# Altium schematic sheet usb_ethernet.SchDoc(usb_ethernet)
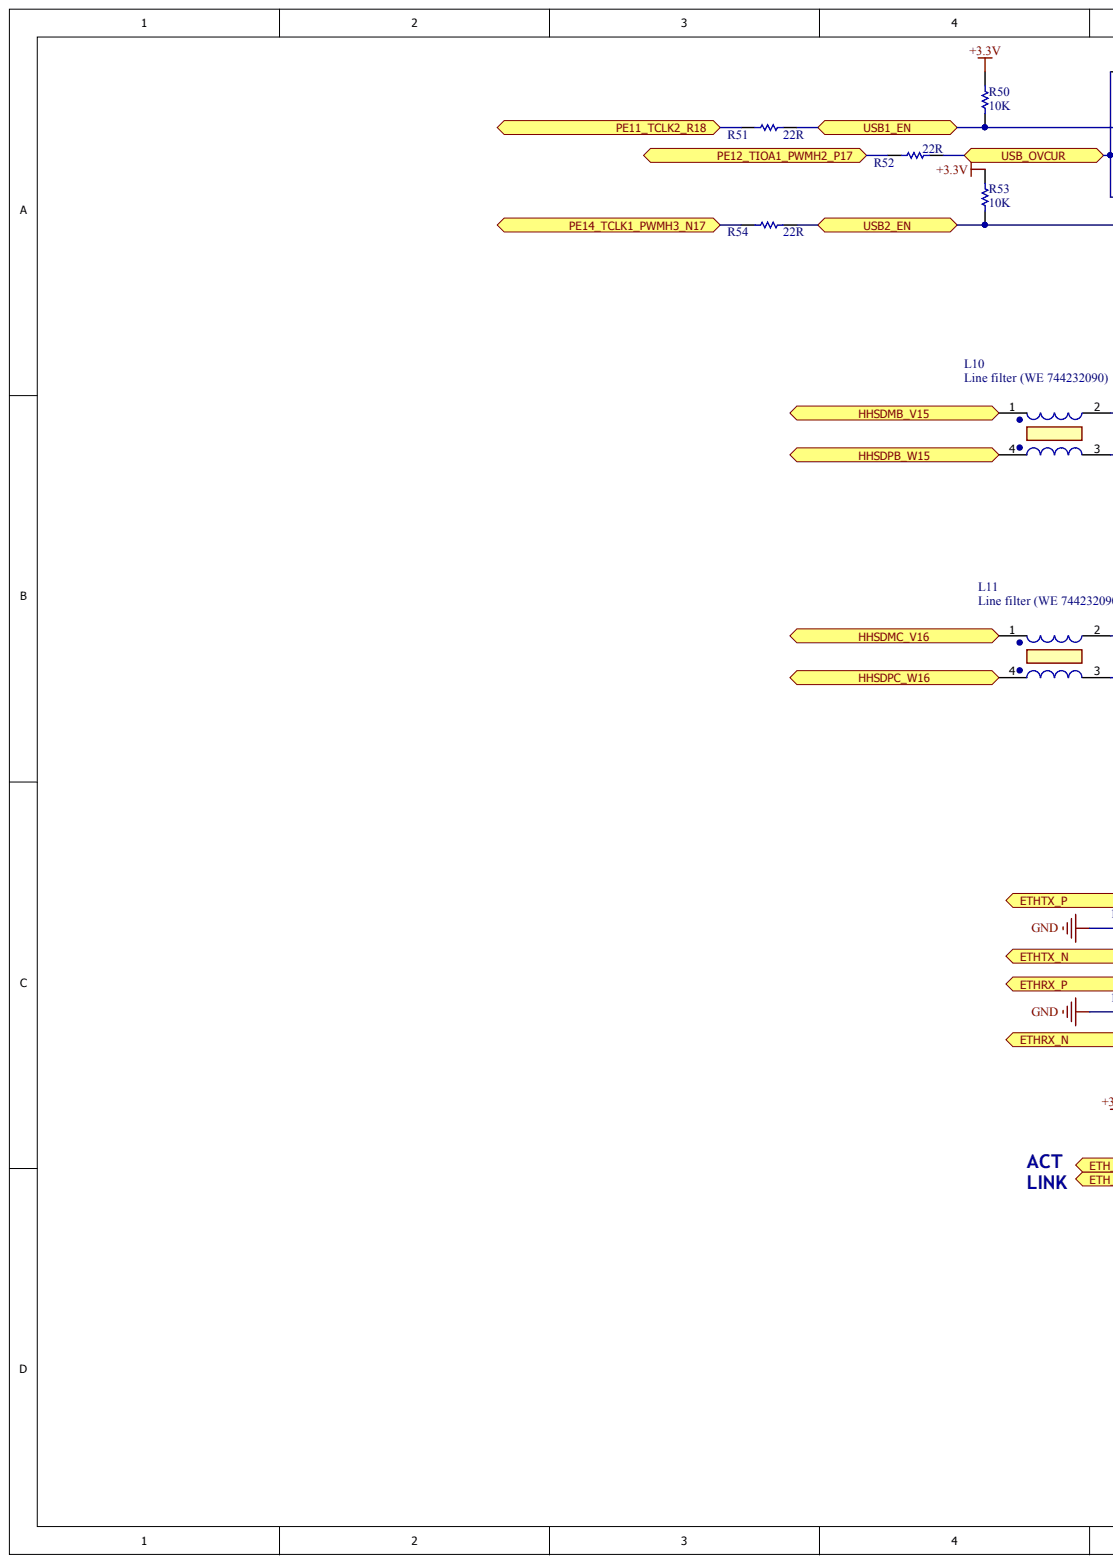
[diagram p0: usb_ethernet.SchDoc, left]
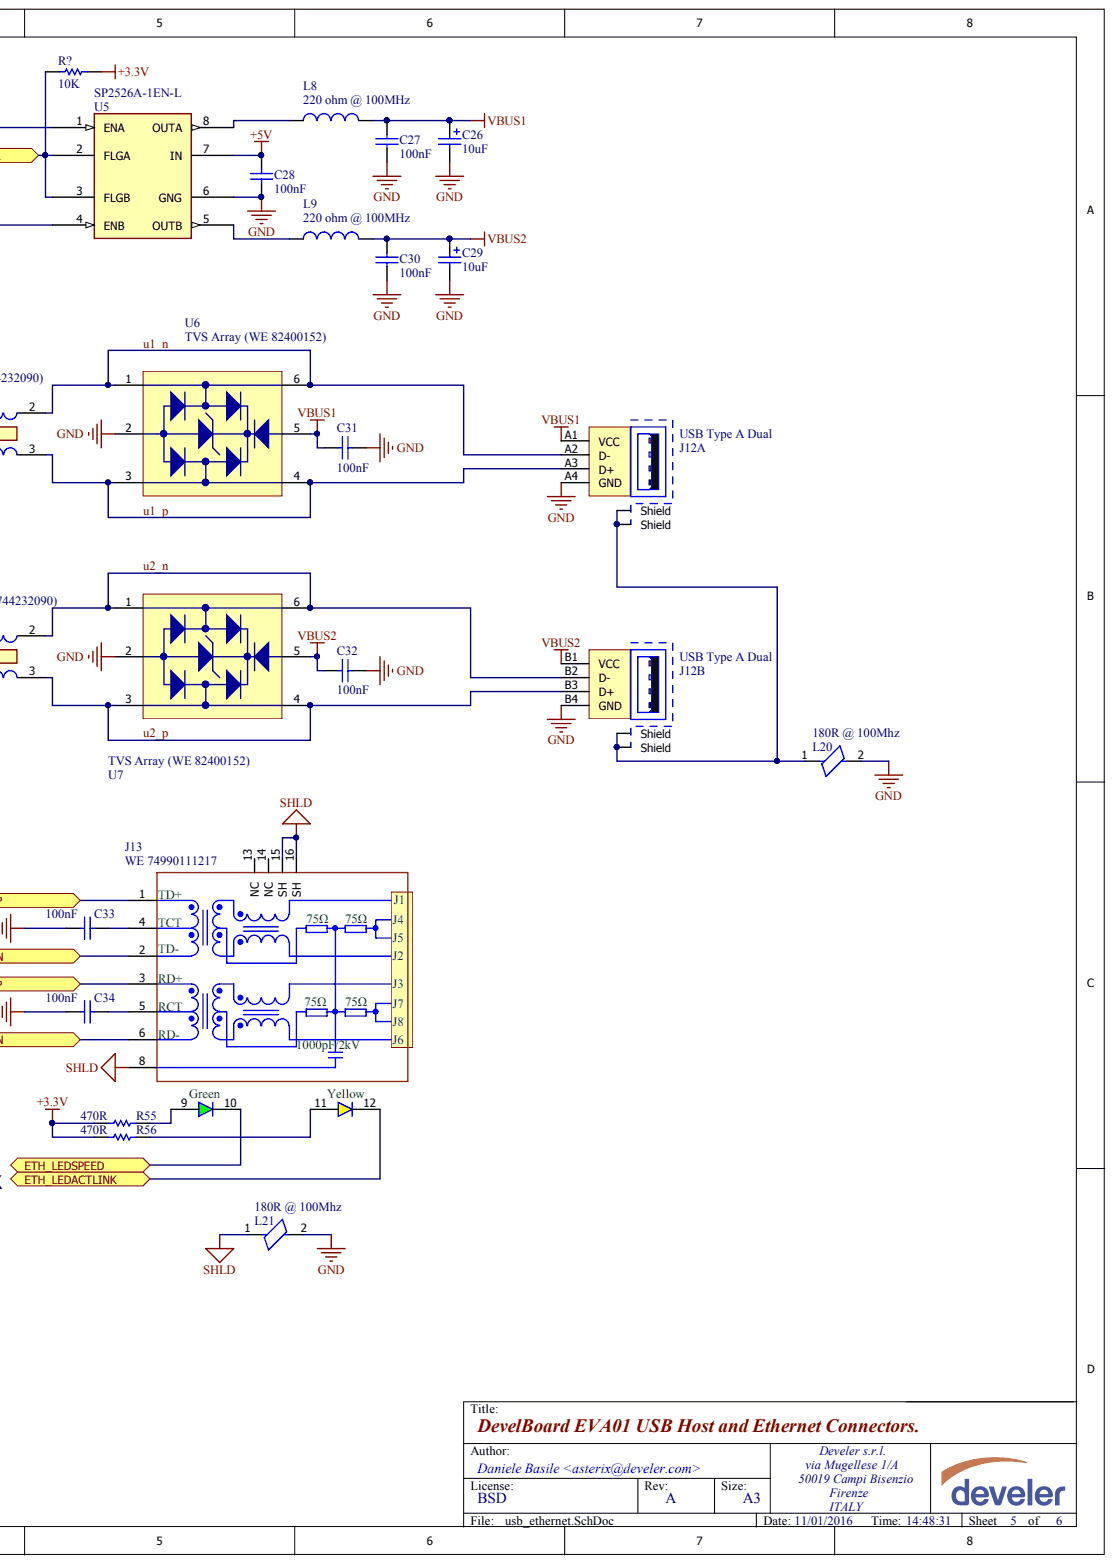
[diagram p1: usb_ethernet.SchDoc, right]

## Parts
C26  10uF  [1206_[3216]]
C27  100nF  [0603_[1608]]
C28  100nF  [0603_[1608]]
C29  10uF  [1206_[3216]]
C30  100nF  [0603_[1608]]
C31  100nF  [0603_[1608]]
C32  100nF  [0603_[1608]]
C33  100nF  [0603_[1608]]
C34  100nF  [0603_[1608]]
J12  USB Type A Dual  [USB_TypeA_Double_THD]  (parts A,B)
J13  WE 74990111217  [RJ45_lan_transformer_horizontal]
L8  220 ohm @ 100MHz  [0805_[2012]]
L9  220 ohm @ 100MHz  [0805_[2012]]
L10  Line filter (WE 744232090)  [WE-CNSW_1206]
L11  Line filter (WE 744232090)  [WE-CNSW_1206]
L20  180R @ 100Mhz  [0603_[1608]]
L21  180R @ 100Mhz  [0603_[1608]]
R50  10K  [0603_[1608]]
R51  22R  [0603_[1608]]
R52  22R  [0603_[1608]]
R53  10K  [0603_[1608]]
R54  22R  [0603_[1608]]
R55  470R  [0603_[1608]]
R56  470R  [0603_[1608]]
R?  10K  [0603_[1608]]
U5  SP2526A-1EN-L  [SOIC8]
U6  TVS Array (WE 82400152)  [SOT-563]
U7  TVS Array (WE 82400152)  [SOT-563]

## Ports
ETH_LEDACTLINK, ETH_LEDSPEED, ETHRX_N, ETHRX_P, ETHTX_N, ETHTX_P, HHSDMB_V15, HHSDMC_V16, HHSDPB_W15, HHSDPC_W16, PE11_TCLK2_R18, PE12_TIOA1_PWMH2_P17, PE14_TCLK1_PWMH3_N17, USB1_EN, USB2_EN, USB_OVCUR

## Nets
+3.3V: R50.1 R53.1 R55.2 R56.2 R?.1
+5V: C28.1 U5.7(IN)
GND: C26.2 C27.2 C28.2 C29.2 C30.2 C31.1 C32.1 C33.2 C34.2 J12.A4(GND) J12.B4(GND) L20.2 L21.2 U5.6(GNG) U6.2 U7.2
SHLD: J13.8 J13.15(SH) J13.16(SH) L21.1
VBUS1: C26.1 C27.1 C31.2 J12.A1(VCC) L8.1 U6.5
VBUS2: C29.1 C30.1 C32.2 J12.B1(VCC) L9.1 U7.5
ETH_LEDACTLINK: J13.12 ; port ETH_LEDACTLINK
ETH_LEDSPEED: J13.10 ; port ETH_LEDSPEED
ETHRX_N: J13.6(RD-) ; port ETHRX_N
ETHRX_P: J13.3(RD+) ; port ETHRX_P
ETHTX_N: J13.2 ; port ETHTX_N
ETHTX_P: J13.1 ; port ETHTX_P
HHSDMB_V15: L10.1 ; port HHSDMB_V15
HHSDMC_V16: L11.1 ; port HHSDMC_V16
HHSDPB_W15: L10.4 ; port HHSDPB_W15
HHSDPC_W16: L11.4 ; port HHSDPC_W16
PE11_TCLK2_R18: R51.1 ; port PE11_TCLK2_R18
PE12_TIOA1_PWMH2_P17: R52.1 ; port PE12_TIOA1_PWMH2_P17
PE14_TCLK1_PWMH3_N17: R54.1 ; port PE14_TCLK1_PWMH3_N17
U1_N: J12.A2(D-) L10.2 U6.1 U6.6
U1_P: J12.A3(D+) L10.3 U6.3 U6.4
U2_N: J12.B2(D-) L11.2 U7.1 U7.6
U2_P: J12.B3(D+) L11.3 U7.3 U7.4
USB1_EN: R50.2 R51.2 U5.1(ENA) ; port USB1_EN
USB2_EN: R53.2 R54.2 U5.4(ENB) ; port USB2_EN
USB_OVCUR: R52.2 R?.2 U5.2(FLGA) U5.3(FLGB) ; port USB_OVCUR
NetC33_1: C33.1 J13.4(TCT)
NetC34_1: C34.1 J13.5(RCT)
NetJ12_Z1: J12.Z1 J12.Z2 J12.Z3 J12.Z4 L20.1
NetJ13_9: J13.9 R55.1
NetJ13_11: J13.11 R56.1
NetL8_2: L8.2 U5.8(OUTA)
NetL9_2: L9.2 U5.5(OUTB)

Not connected: J13 13(NC) 14(NC)

## Library data of the parts
C26 C29 = Cap Pol1: Ceramic X5R 25V; Component Kind: Standard; Published: 8-Jun-2000; Revision: July-2002: Re-released for DXP Platform.; Signal Integrity: Cap Pol; Simulation: CAP; Value: 10uF; Library Name: DEVELER_Library.SchLib; Publisher: Altium Limited
C27 C28 C30-C32 = Cap: Ceramic X7R 50V; Value: 100pF; Component Kind: Standard; LatestRevisionDate: 17-Jul-2002; LatestRevisionNote: Re-released for DXP Platform.; Library Name: Miscellaneous Devices.IntLib; PackageDescription: Radial Cap, Thru-Hole; 2 Leads; 0.3 in Pin Spacing; PackageReference: RAD-0.3; Published: 8-Jun-2000; Signal Integrity: Cap; Simulation: CAP; Publisher: Altium Limited
C33 C34 = Cap: Capacitor; Value: 10nF; Component Kind: Standard; Library Name: project42_lib.SchLib; Published: 8-Jun-2000; Revision: July-2002: Re-released for DXP Platform.; Signal Integrity: Cap; Simulation: CAP; Publisher: Altium Limited
J12 = WE-Communication Connectors 61400826021: USB Type-A Double Connector (WE  61400826021); Manufacturer: Würth Elektronik eiSos GmbH; ComponentLink1 Description: Manufacturer; ComponentLink2 Description: Datasheet; NoPins: 8; Component Kind: Standard; ComponentLink1URL: http://www.we-online.de; ComponentLink2URL: http://katalog.we-online.de/em/datasheet/61400826021.pdf; Created Date: 10/10/2014; Library Name: 61400826021.IntLib; partNo: 61400826021; Created by: Karrer Zheng
J13 = RJ45LAN-10/100BaseT: Integrated RJ45 Transformer WE-RJ45LAN; Manufacturer: Wurth Elektronik; PartNo: 74980111211; E-mail: libraries@we-online.com; Component Kind: Standard; ComponentLink1Description: Manufacturer; ComponentLink1URL: http://www.we-online.de; ComponentLink2Description: Datasheet; ComponentLink2URL: http://www.we-online.de/katalog/media/pdf/74980111211.pdf; Created Date: Feb 2015; Created by: Karrer Zheng; Library Name: DEVELER_Library.SchLib
L8 L9 = Inductor: WE 742792022; Component Kind: Standard; Library Name: DEVELER_Library.SchLib
L10 L11 = Common_mode_choke: Common mode line filter; Component Kind: Standard; Library Name: DEVELER_Library.SchLib
L20 L21 = BEAD_ FERRITE: Ferrite Beat (WE 7427927218); Component Kind: Standard; Library Name: project42_lib.SchLib
R50-R56 R? = Res1: Resistor; Value: 1K; Component Kind: Standard; Published: 8-Jun-2000; Revision: July-2002: Re-released for DXP Platform.; Signal Integrity: Res; Simulation: RESISTOR; Library Name: DEVELER_Library.SchLib; Publisher: Altium Limited
U6 U7 = TVS_Diode_Array: Component Kind: Standard; Library Name: DEVELER_Library.SchLib
U5 = SP2526A-1EN-L: Component Kind: Standard; Description: SP2526A-1EN-L; Library Name: DEVELER_Library.SchLib
